# Altium schematic sheet bolid-iso-motor-control.SchDoc
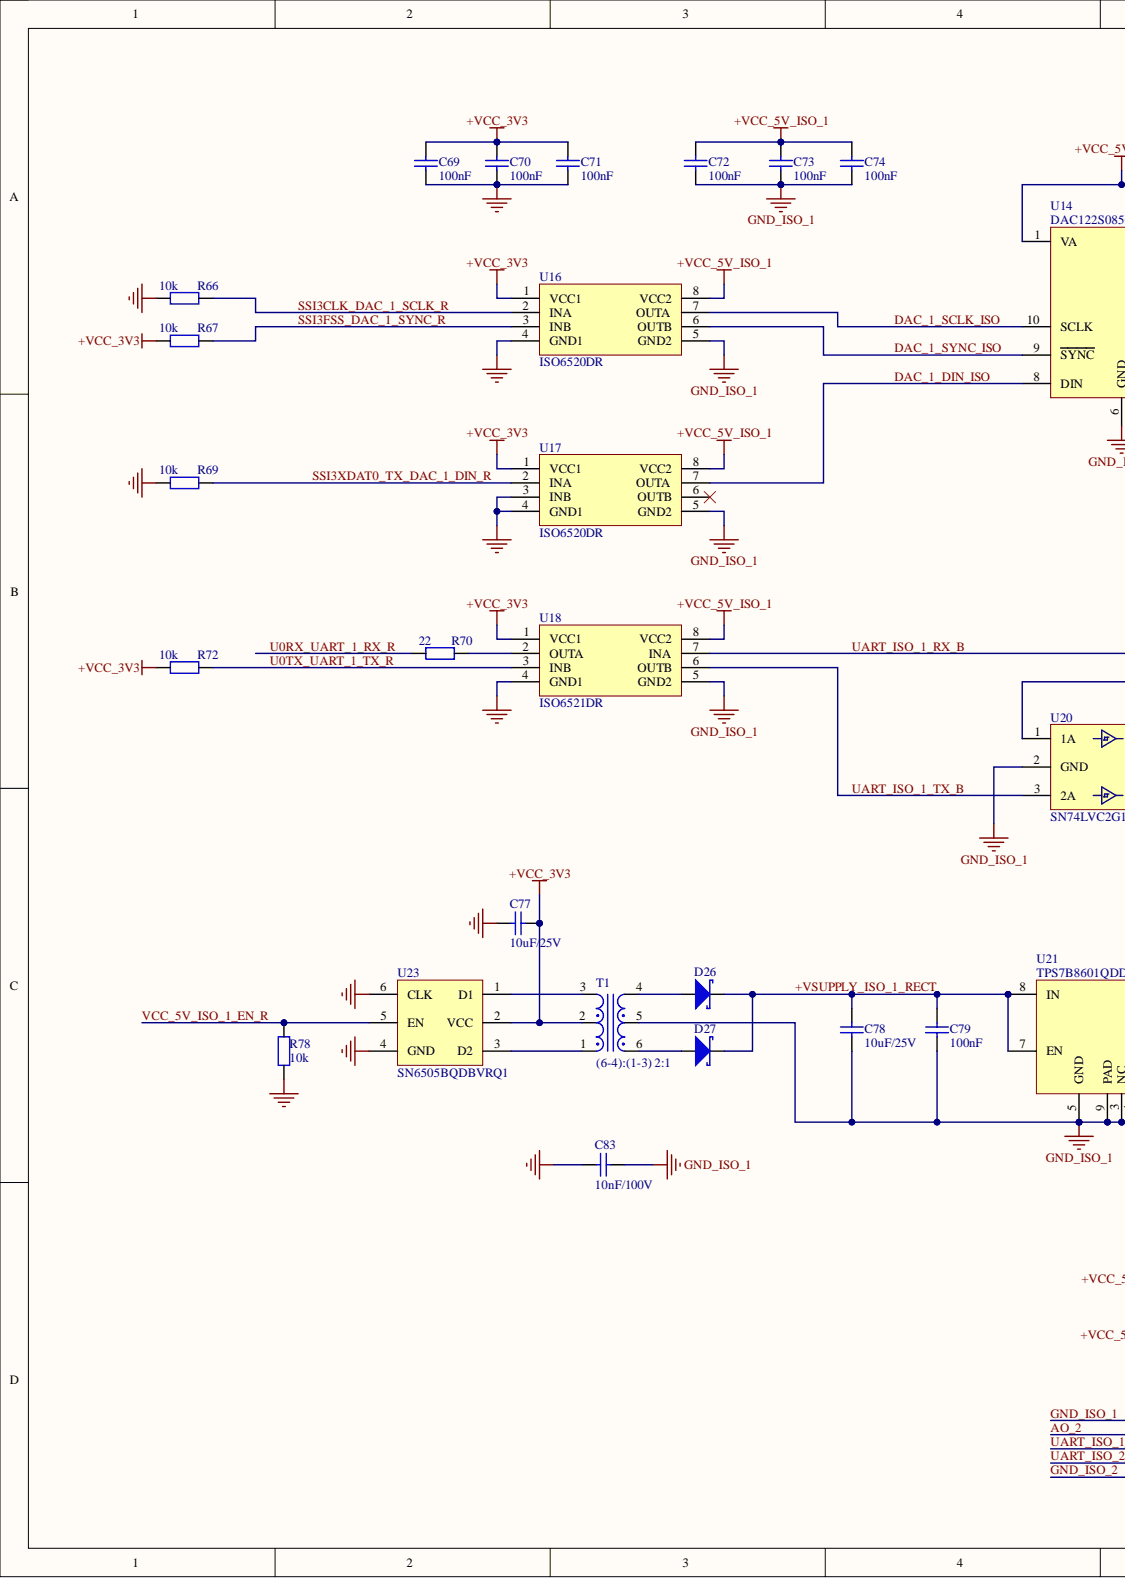
[diagram p0: bolid-iso-motor-control.SchDoc, left]
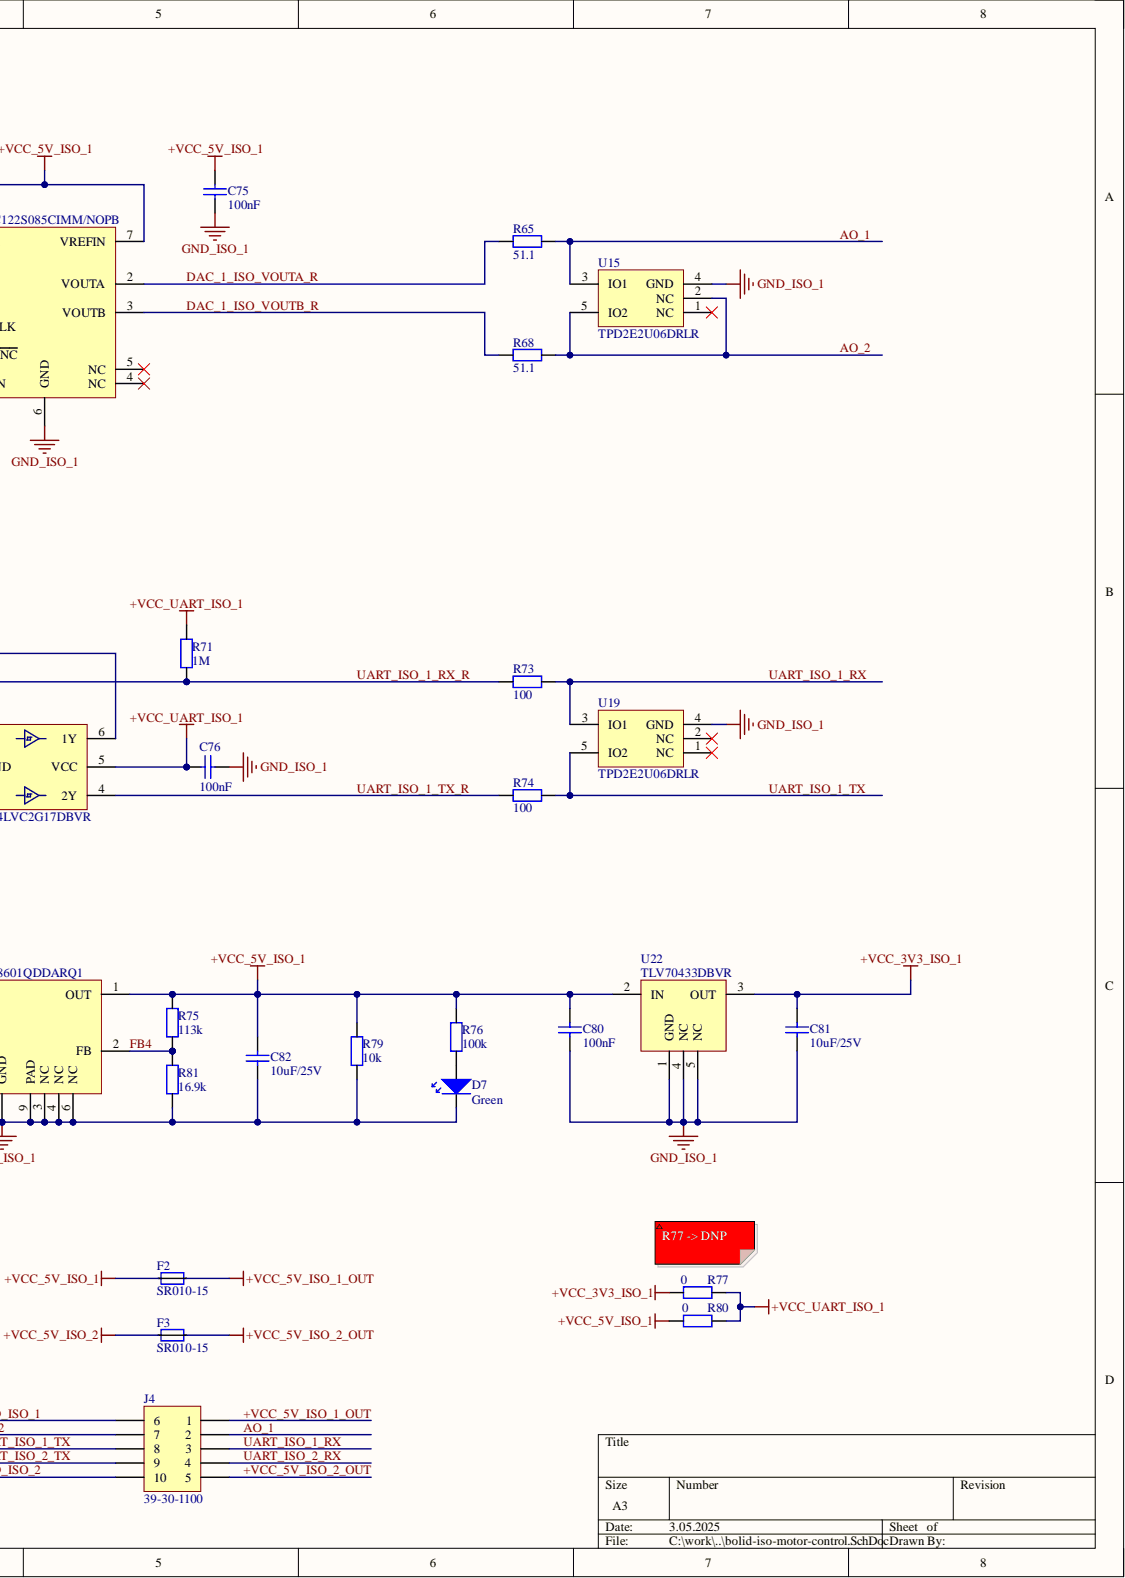
[diagram p1: bolid-iso-motor-control.SchDoc, right]

## Parts
C69  value 100nF  [C0402-CAPC100X50X55L22 - Tayio Yuden TDK]  (hidden comment: GRM155R71H104KE14D)
C70  value 100nF  [C0402-CAPC100X50X55L22 - Tayio Yuden TDK]  (hidden comment: GRM155R71H104KE14D)
C71  value 100nF  [C0402-CAPC100X50X55L22 - Tayio Yuden TDK]  (hidden comment: GRM155R71H104KE14D)
C72  value 100nF  [C0402-CAPC100X50X55L22 - Tayio Yuden TDK]  (hidden comment: GRM155R71H104KE14D)
C73  value 100nF  [C0402-CAPC100X50X55L22 - Tayio Yuden TDK]  (hidden comment: GRM155R71H104KE14D)
C74  value 100nF  [C0402-CAPC100X50X55L22 - Tayio Yuden TDK]  (hidden comment: GRM155R71H104KE14D)
C75  value 100nF  [C0402-CAPC100X50X55L22 - Tayio Yuden TDK]  (hidden comment: GRM155R71H104KE14D)
C76  value 100nF  [C0402-CAPC100X50X55L22 - Tayio Yuden TDK]  (hidden comment: GRM155R71H104KE14D)
C77  Value Displayed: 10uF/25V  [C1206-CAPC320X160X190L65N - Murata]  (hidden comment: TMK316AB7106KL-T)
C78  Value Displayed: 10uF/25V  [C1206-CAPC320X160X190L65N - Murata]  (hidden comment: TMK316AB7106KL-T)
C79  value 100nF  [C0402-CAPC100X50X55L22 - Tayio Yuden TDK]  (hidden comment: GRM155R71H104KE14D)
C80  value 100nF  [C0402-CAPC100X50X55L22 - Tayio Yuden TDK]  (hidden comment: GRM155R71H104KE14D)
C81  Value Displayed: 10uF/25V  [C1206-CAPC320X160X190L65N - Murata]  (hidden comment: TMK316AB7106KL-T)
C82  Value Displayed: 10uF/25V  [C1206-CAPC320X160X190L65N - Murata]  (hidden comment: TMK316AB7106KL-T)
C83  Value Displayed: 10nF/100V  [C0603-CAPC162X80X95L35N]  (hidden comment: GRM188R72A103KA01J)
D7  Illumination Color: Green  [D0603 DIONC166X84X36L42N Littelfuse]  (hidden comment: 150060GS75000)
D26  MBR0530T3G  [SOD-123-2L SOD375X160X128L32X60N - Fairchild]  (value not drawn)
D27  MBR0530T3G  [SOD-123-2L SOD375X160X128L32X60N - Fairchild]  (value not drawn)
F2  SR010-15  [RESC2214X10N - SR010-15]
F3  SR010-15  [RESC2214X10N - SR010-15]
J4  39-30-1100  [MOLEX Mini-Fit Jr 039301100 2x5 PEG]
R65  value 51.1  [R0402-RESC100X50X40L22N]  (hidden comment: AC0402FR-0751R1L)
R66  value 10k  [R0402-RESC100X50X40L22N]  (hidden comment: RC0402FR-0710KL)
R67  value 10k  [R0402-RESC100X50X40L22N]  (hidden comment: RC0402FR-0710KL)
R68  value 51.1  [R0402-RESC100X50X40L22N]  (hidden comment: AC0402FR-0751R1L)
R69  value 10k  [R0402-RESC100X50X40L22N]  (hidden comment: RC0402FR-0710KL)
R70  value 22  [R0402-RESC100X50X40L22N]  (hidden comment: RC0402FR-1322RL)
R71  value 1M  [R0402-RESC100X50X40L22N]  (hidden comment: AC0402FR-071ML)
R72  value 10k  [R0402-RESC100X50X40L22N]  (hidden comment: RC0402FR-0710KL)
R73  value 100  [R0402-RESC100X50X40L22N]  (hidden comment: RC0402FR-07100RL)
R74  value 100  [R0402-RESC100X50X40L22N]  (hidden comment: RC0402FR-07100RL)
R75  value 113k  [R0402-RESC100X50X40L22N]  (hidden comment: RC0402FR-07113KL)
R76  value 100k  [R0402-RESC100X50X40L22N]  (hidden comment: RC0402FR-07100KL)
R77  value 0  [R0402-RESC100X50X40L22N]  (hidden comment: RC0402JR-7D0RL)
R78  value 10k  [R0402-RESC100X50X40L22N]  (hidden comment: RC0402FR-0710KL)
R79  value 10k  [R0402-RESC100X50X40L22N]  (hidden comment: RC0402FR-0710KL)
R80  value 0  [R0402-RESC100X50X40L22N]  (hidden comment: RC0402JR-7D0RL)
R81  value 16.9k  [R0402-RESC100X50X40L22N]  (hidden comment: RC0402FR-0716K9L)
T1  value (6-4):(1-3) 2:1  [WE 760390014]  (hidden comment: 760390015)
U14  DAC122S085CIMM/NOPB  [TI VSSOP10 DGS0010A TSSOP50P490X110-10N]
U15  TPD2E2U06DRLR  [TI SOT-5X3 DRL0005A SOTFL50P160X60-5N]
U16  ISO6520DR  [TI SOIC8 D0008A SOIC8P127_490X599X175L84X41N]
U17  ISO6520DR  [TI SOIC8 D0008A SOIC8P127_490X599X175L84X41N]
U18  ISO6521DR  [TI SOIC8 D0008A SOIC8P127_490X599X175L84X41N]
U19  TPD2E2U06DRLR  [TI SOT-5X3 DRL0005A SOTFL50P160X60-5N]
U20  SN74LVC2G17DBVR  [TI SOT23 DBV0006A SOT23-6P95_280X145L45X37N]
U21  TPS7B8601QDDARQ1  [TI SOIC8 DDA0008E-C01 SOIC127P600X170_HS-9N]
U22  TLV70433DBVR  [TI SOT23 DBV0005A SOT23-5P95_280X145L45X40N]
U23  SN6505BQDBVRQ1  [TI SOT23 DBV0006A SOT23-6P95_280X145L45X37N]

## Nets
+VCC_3V3: C69.2 C70.2 C71.2 C77.2 R67.2 R72.2 T1.2 U16.1(VCC1) U17.1(VCC1) U18.1(VCC1) U23.2(VCC)
+VCC_3V3_ISO_1: C81.2 R77.1 U22.3(OUT)
+VCC_5V_ISO_1: C72.2 C73.2 C74.2 C75.2 C80.2 C82.2 F2.1 R75.2 R76.2 R79.2 R80.1 U14.1(VA) U14.7(VREFIN) U16.8(VCC2) U17.8(VCC2) U18.8(VCC2) U21.1(OUT) U22.2(IN)
+VCC_5V_ISO_2: F3.1
+VCC_UART_ISO_1: C76.2 R71.2 R77.2 R80.2 U20.5(VCC)
GND: C69.1 C70.1 C71.1 C77.1 C83.1 R66.2 R69.2 R78.1 U16.4(GND1) U17.3(INB) U17.4(GND1) U18.4(GND1) U23.4(GND) U23.6(CLK)
+VCC_5V_ISO_1_OUT: F2.2 J4.1
+VCC_5V_ISO_2_OUT: F3.2 J4.5
+VSUPPLY_ISO_1_RECT: C78.2 C79.2 D26.1 D27.1 U21.7(EN) U21.8(IN)
AO_1: J4.2 R65.2 U15.3(IO1)
AO_2: J4.7 R68.2 U15.2(NC) U15.5(IO2)
DAC_1_DIN_ISO: U14.8(DIN) U17.7(OUTA)
DAC_1_ISO_VOUTA_R: R65.1 U14.2(VOUTA)
DAC_1_ISO_VOUTB_R: R68.1 U14.3(VOUTB)
DAC_1_SCLK_ISO: U14.10(SCLK) U16.7(OUTA)
DAC_1_SYNC_ISO: U14.9(SYNC) U16.6(OUTB)
FB4: R75.1 R81.2 U21.2(FB)
GND_ISO_1: C72.1 C73.1 C74.1 C75.1 C76.1 C78.1 C79.1 C80.1 C81.1 C82.1 C83.2 D7.1 J4.6 R79.1 R81.1 T1.5 U14.6(GND) U15.4(GND) U16.5(GND2) U17.5(GND2) U18.5(GND2) U19.4(GND) U20.2(GND) U21.3(NC) U21.4(NC) U21.5(GND) U21.6(NC) U21.9(PAD) U22.1(GND) U22.4(NC) U22.5(NC)
GND_ISO_2: J4.10
SSI3CLK_DAC_1_SCLK_R: R66.1 U16.2(INA)
SSI3FSS_DAC_1_SYNC_R: R67.1 U16.3(INB)
SSI3XDAT0_TX_DAC_1_DIN_R: R69.1 U17.2(INA)
U0RX_UART_1_RX_R: R70.1
U0TX_UART_1_TX_R: R72.1 U18.3(INB)
UART_ISO_1_RX: J4.3 R73.2 U19.3(IO1)
UART_ISO_1_RX_B: U18.7(INA) U20.6(1Y)
UART_ISO_1_RX_R: R71.1 R73.1 U20.1(1A)
UART_ISO_1_TX: J4.8 R74.2 U19.5(IO2)
UART_ISO_1_TX_B: U18.6(OUTB) U20.3(2A)
UART_ISO_1_TX_R: R74.1 U20.4(2Y)
UART_ISO_2_RX: J4.4
UART_ISO_2_TX: J4.9
VCC_5V_ISO_1_EN_R: R78.2 U23.5(EN)
NetD7_2: D7.2 R76.1
NetD26_2: D26.2 T1.4
NetD27_2: D27.2 T1.6
NetR70_2: R70.2 U18.2(OUTA)
NetT1_1: T1.1 U23.3(D2)
NetT1_3: T1.3 U23.1(D1)

Not connected: U14 4(NC) 5(NC); U15 1(NC); U17 6(OUTB); U19 1(NC) 2(NC)

## Library data of the parts
C69-C76 C79 C80 = GRM155R71H104KE14D: MLCC 0402 (1005) 100nF 10% 50V X7R; Brand: Murata Electronics; Capacitance: 0.1 uF; Capacitance - pF: 100000 pF; Class: Class 2; Type: Chip Multilayer Ceramic Capacitor for General Purpose; Voltage Rating DC: 50 VDC; Capacitance - nF: 100 nF; Case Code - in: 0402; Case Code - mm: 1005; Category: Multilayer Ceramic Capacitors MLCC - SMD/SMT; Component Kind: Standard; ComponentLink1Description: https://www.mouser.com/datasheet/2/281/murata_03052018_GRM_Series_1-1310166.pdf; ComponentLink1URL: https://www.mouser.com/datasheet/2/281/murata_03052018_GRM_Series_1-1310166.pdf; Dielectric: X7R; Height: 0.5 mm; Length: 1 mm; Library Name: capacitors2.IntLib; Manufacturer: Murata Electronics; Manufacturer Part Number: GRM155R71H104KE14D; Maximum Operating Temperature: + 125 C; Minimum Operating Temperature: - 55 C; Minimum Order Quantity: 1; Package / Case: 0402 (1005 metric); Packaging: Cut Tape; Pricing 1: 1=0.090, 10=0.038, 100=0.016, 500=0.012, 1000=0.009, 2500=0.008, 5000=0.007, 10000=0.005 (EUR); Product: General Type MLCCs; Product Type: Ceramic Capacitors; Reeling: True; RoHS: RoHS Compliant; RoHS - Mouser: Y; Series: GRM; Signal Integrity: Cap Semi; Simulation: CAP; Standard Pack Qty: 10000; Stock 1: 3511637; Subcategory: Capacitors; Supplier 1: Mouser; Supplier Part Number 1: 81-GRM155R71H104KE4D; Termination: Standard; Termination Style: SMD/SMT; Tolerance: 10 %; Value Displayed: 100nF; Width: 0.5 mm
C77 C78 C81 C82 = TMK316AB7106KL-T: MLCC 1206 (3216) 10uF 10% 25V X7R; Brand: Taiyo Yuden; Class: Class 2; Type: General Purpose; Value: 10u; Voltage Rating DC: 25 VDC; Capacitance: 10 uF; Case Code - in: 1206; Case Code - mm: 3216; Category: Multilayer Ceramic Capacitors MLCC - SMD/SMT; Component Kind: Standard; ComponentLink1Description: https://www.mouser.com/datasheet/2/396/mlcc02_e-1307760.pdf; ComponentLink1URL: https://www.mouser.com/datasheet/2/396/mlcc02_e-1307760.pdf; Diameter: -; Dielectric: X7R; Height: 1.6 mm; Length: 3.2 mm; Library Name: capacitors2.IntLib; Manufacturer: Taiyo Yuden; Manufacturer Part Number: TMK316AB7106KL-T; Maximum Operating Temperature: + 125 C; Minimum Operating Temperature: - 55 C; Minimum Order Quantity: 1; Package / Case: 1206 (3216 metric); Packaging: Cut Tape; Pricing 1: 1=0.288, 10=0.130, 100=0.087, 500=0.077, 1000=0.068, 2000=0.056 (EUR); Product: General Type MLCCs; Product Type: Ceramic Capacitors; Reeling: True; RoHS: RoHS Compliant; RoHS - Mouser: Y; Series: M; Signal Integrity: Cap Semi; Simulation: CAP; Standard Pack Qty: 2000; Stock 1: 162457; Subcategory: Capacitors; Supplier 1: Mouser; Supplier Part Number 1: 963-TMK316AB7106KL-T; Termination: Standard; Termination Style: SMD/SMT; Tolerance: 10 %; Width: 1.6 mm
C83 = GRM188R72A103KA01J: MLCC 0603 (1608) 10nF 10% 100V X7R; Brand: Murata Electronics; Capacitance: 0.01 uF; Capacitance - pF: 10000 pF; Class: Class 2; Type: Chip Multilayer Ceramic Capacitor for General Purpose; Value: 10n; Voltage Rating DC: 100 VDC; Capacitance - nF: 10 nF; Case Code - in: 0603; Case Code - mm: 1608; Category: Multilayer Ceramic Capacitors MLCC - SMD/SMT; Component Kind: Standard; ComponentLink1Description: https://www.mouser.com/datasheet/2/281/1/GRM188R72A103KA01_01A-1985966.pdf; ComponentLink1URL: https://www.mouser.com/datasheet/2/281/1/GRM188R72A103KA01_01A-1985966.pdf; Dielectric: X7R; Height: 0.8 mm; Length: 1.6 mm; Library Name: capacitors2.IntLib; Manufacturer: Murata Electronics; Manufacturer Part Number: GRM188R72A103KA01J; Maximum Operating Temperature: + 125 C; Minimum Operating Temperature: - 55 C; Minimum Order Quantity: 1; Package / Case: 0603 (1608 metric); Packaging: Cut Tape; Pricing 1: 1=0.085, 10=0.049, 100=0.021, 500=0.015, 1000=0.011, 5000=0.010, 10000=0.008, 20000=0.007 (EUR); Product: General Type MLCCs; Product Type: Ceramic Capacitors; Reeling: True; RoHS: RoHS Compliant; RoHS - Mouser: Y; Series: GRM; Signal Integrity: Cap Semi; Simulation: CAP; Standard Pack Qty: 10000; Stock 1: 161253; Subcategory: Capacitors; Supplier 1: Mouser; Supplier Part Number 1: 81-GRM188R72A103KA1J; Termination: Standard; Termination Style: SMD/SMT; Tolerance: 10 %; Width: 0.8 mm
D7 = 150060GS75000: LED 0603 (1608) Green; Brand: Wurth Elektronik; If - Forward Current: 20 mA; Lens Color/Style: Water Clear; Lens Shape: Rectangular; Luminous Intensity: 430 mcd; Peak Wavelength: 515 nm; Power Rating: 105 mW; Vf - Forward Voltage: 3.2 V; Viewing Angle: 140 deg; Vr - Reverse Voltage: 5 V; Wavelength/Color Temperature: 520 nm; Category: Standard LEDs - SMD; Component Kind: Standard; ComponentLink1Description: https://www.mouser.com/datasheet/2/445/150060GS75000-368921.pdf; ComponentLink1URL: https://www.mouser.com/datasheet/2/445/150060GS75000-368921.pdf; Height: 0.7 mm; Length: 1.6 mm; Lens Dimensions: 1.1 mm x 0.8 mm; Lens Transparency: Water Clear; Library Name: semiconductors2.IntLib; Manufacturer: Wurth Elektronik; Manufacturer Part Number: 150060GS75000; Maximum Operating Temperature: + 85 C; Minimum Operating Temperature: - 40 C; Minimum Order Quantity: 1; Moisture Sensitive: Yes; Mounting Style: SMD/SMT; Package / Case: 0603 (1608 metric); Packaging: Cut Tape; Pricing 1: 1=0.130, 10=0.126, 50=0.115, 100=0.099, 500=0.085, 4000=0.085 (EUR); Product: Green LEDs; Product Type: LED - Standard; Reeling: True; RoHS: RoHS Compliant; RoHS - Mouser: Y; Series: WL-SMCW; Signal Integrity: Diode; Simulation: DIODE; Standard Pack Qty: 4000; Stock 1: 17312; Subcategory: LEDs; Supplier 1: Mouser; Supplier Part Number 1: 710-150060GS75000; Width: 0.8 mm
D26 D27 = MBR0530T3G: ON Semi MBR0530T3G; SMT Schottky Diode; 30V 500mA; 2-Pin SOD-123; Manufacturer: ON Semiconductor; Category: Schottky Diodes; Component Kind: Standard; ComponentLink1Description: https://datasheet.ciiva.com/6381/mbr0530t1-d-6381904.pdf?src-supplier=Digikey; ComponentLink1URL: https://datasheet.ciiva.com/6381/mbr0530t1-d-6381904.pdf?src-supplier=Digikey; ComponentLink2Description: https://datasheet.ciiva.com/7962/85890-7962703.pdf?src-supplier=Element14; ComponentLink2URL: https://datasheet.ciiva.com/7962/85890-7962703.pdf?src-supplier=Element14; ComponentLink3Description: https://datasheet.ciiva.com/8294/mbr0530t1-d-8294572.pdf?src-supplier=Avnet; ComponentLink3URL: https://datasheet.ciiva.com/8294/mbr0530t1-d-8294572.pdf?src-supplier=Avnet; ComponentLink4Description: https://datasheet.ciiva.com/15144/getdatasheetpartid-86762-15144599.pdf?src-supplier=Verical; ComponentLink4URL: https://datasheet.ciiva.com/15144/getdatasheetpartid-86762-15144599.pdf?src-supplier=Verical; ComponentLink5Description: https://datasheet.ciiva.com/6381/mbr0530t1-d-6381902.pdf?src-supplier=Digikey; ComponentLink5URL: https://datasheet.ciiva.com/6381/mbr0530t1-d-6381902.pdf?src-supplier=Digikey; Library Name: semiconductors2.IntLib; Manufacturer Part Number: MBR0530T3G; Pricing 1: 1=0,19, 10=0,126, 50=0,126, 100=0,065, 1000=0,053, 10000=0,041 (USD); RoHS: Yes; Signal Integrity: Diode; Simulation: DIODE; Stock 1: 167531; Supplier 1: Mouser; Supplier Part Number 1: 863-MBR0530T3G
F2 F3 = SR010-15: PTC Fuse Hold Current 0.10A, Trip Current 0.30A VMax 15V; Manufacturer: Excel Cell; Component Kind: Standard; Library Name: misc2.IntLib; Manufacturer Part Number: SR010-15; RoHS: No; Stock 1: 5574; Supplier 1: TME; Supplier Part Number 1: SR010-15
J4 = 039301100: Molex MiniFit Jr 2x5 Peg Rt Angle Header Tin Plate W/pcb Mount Peg 94V-2 10 Circt | Molex Incorporated 39-30-1100; Gender: 29Gender; Insulation Resistance: 1GR; Number of Circuits: 8NUMBER; Number of Ports: 1NUMBER; Voltage Rating (DC): 600V; Category: Headers and Wire Housings; Component Kind: Standard; ComponentLink1Description: https://datasheet.ciiva.com/30070/136790039301100-pcb-headers-30070445.pdf?src-supplier=Onlinecomponents.com; ComponentLink1URL: https://datasheet.ciiva.com/30070/136790039301100-pcb-headers-30070445.pdf?src-supplier=Onlinecomponents.com; ComponentLink2Description: https://datasheet.ciiva.com/11748/0900766b805f2c40-11748682.pdf?src-supplier=Rs; ComponentLink2URL: https://datasheet.ciiva.com/11748/0900766b805f2c40-11748682.pdf?src-supplier=Rs; Depth: 19.4mm; Fastening Type: 192FasteningType; Housing Color: 50Colour; Lead Free: 5697LeadFree; Library Name: connectors2.IntLib; Manufacturer: Molex; Manufacturer Part Number: 39-30-1100; Pricing 1: 1=0,85, 10=0,769, 50=0,769, 100=0,739, 1000=0,739, 10000=0,739 (USD); RoHS: Yes; Stock 1: 3513; Supplier 1: Mouser; Supplier Part Number 1: 538-39-30-1100
R65 R68 = AC0402FR-0751R1L: RES 0402 (1005) 51.1 ohm 1% 0.0625W 50V Thick Film; Manufacturer: Yageo; Max Power Dissipation: 63mW; Power Rating: 62.5mW; Resistance: 51.1R; Temperature Coefficient: 100ppm/°C; Case Code (Imperial): 0402; Case Code (Metric): 1005; Case/Package: 0402; Category: Chip SMD Resistors; Component Kind: Standard; ComponentLink1Description: http://www.mouser.com/ds/2/447/yageodocoutput-1111992.pdf?src-supplier=Mouser; ComponentLink1URL: http://www.mouser.com/ds/2/447/yageodocoutput-1111992.pdf?src-supplier=Mouser; ComponentLink2Description: http://www.yageo.com/NewPortal/yageodocoutput?fileName=/pdf/R-Chip/PYu-AC_51_RoHS_L_6.pdf&src-supplier=Digi-Key; ComponentLink2URL: http://www.yageo.com/NewPortal/yageodocoutput?fileName=/pdf/R-Chip/PYu-AC_51_RoHS_L_6.pdf&src-supplier=Digi-Key; Features: Moisture Resistant,Automotive AEC-Q200; Height: 0.015inch; Length: 0.039inch; Library Name: resistors2.IntLib; Manufacturer Part Number: AC0402FR-0751R1L; Max Operating Temperature: 155°C; Min Operating Temperature: -55°C; Number of Terminations: 2; Packaging: Tape and Reel; Pricing 1: 1=0,10000, 10=0,01500, 50=0,01500, 100=0,00700, 1000=0,00300, 10000=0,00200 (USD); Resistor Type: Thick Film; RoHS: Yes; RoHS Compliant: Yes; Series: AC; Signal Integrity: Res; Simulation: RESISTOR; Stock 1: 57995; Supplier 1: Mouser; Supplier Part Number 1: 603-AC0402FR-0751R1L; Termination: SMD/SMT; Tolerance: 1%; Voltage Rating: 50V; Width: 0.02inch
R66 R67 R69 R72 R78 R79 = RC0402FR-0710KL: RES 0402 (1005) 10.0k 1% 0.0625W 50V Thick Film; Brand: Yageo; Power Rating: 62.5 mW, 125 mW; Resistance: 10 kOhms; Temperature Coefficient: 100 PPM / C; Application: High Reliability; Case Code - in: 0402; Case Code - mm: 1005; Category: Thick Film Resistors - SMD; Component Kind: Standard; ComponentLink1Description: https://www.mouser.com/datasheet/2/447/PYu-RC_Group_51_RoHS_L_10-1664068.pdf; ComponentLink1URL: https://www.mouser.com/datasheet/2/447/PYu-RC_Group_51_RoHS_L_10-1664068.pdf; Features: -; Height: 0.35 mm; Length: 1 mm; Library Name: resistors2.IntLib; Manufacturer: Yageo; Manufacturer Part Number: RC0402FR-0710KL; Maximum Operating Temperature: + 155 C; Minimum Operating Temperature: - 55 C; Minimum Order Quantity: 1; Mounting Style: PCB Mount; Package / Case: -; Packaging: Cut Tape; Pricing 1: 1=0.090, 10=0.011, 100=0.004, 1000=0.003, 10000=0.003 (EUR); Product: Thick Film Resistors SMD; Product Type: Thick Film Resistors; Reeling: True; RoHS: RoHS Compliant; RoHS - Mouser: Y; Series: RC; Signal Integrity: Res; Simulation: RESISTOR; Standard Pack Qty: 10000; Stock 1: 20942447; Subcategory: Resistors; Supplier 1: Mouser; Supplier Part Number 1: 603-RC0402FR-0710KL; Technology: Thick Film; Termination Style: SMD/SMT; Tolerance: 1 %; Type: General Purpose; Voltage Rating: 50 V; Width: 0.5 mm
R70 = RC0402FR-1322RL: RES 0402 (1005) 22.0 ohm 1% 0.0625W 50V Thick Film; Manufacturer: Yageo; Power Rating: 62.5mW; Resistance: 22R; Temperature Coefficient: 100ppm/°C; Case Code (Imperial): 0402; Case Code (Metric): 1005; Case/Package: 0402; Category: Chip SMD Resistors; Component Kind: Standard; ComponentLink1Description: https://datasheet.ciiva.com/17423/pyu-rc0402-51-rohs-l-6-r-17423721.pdf?src-supplier=Future+Electronics; ComponentLink1URL: https://datasheet.ciiva.com/17423/pyu-rc0402-51-rohs-l-6-r-17423721.pdf?src-supplier=Future+Electronics; ComponentLink2Description: https://datasheet.ciiva.com/8890/pyu-rc0402-51-rohs-l-6-8890187.pdf?src-supplier=Avnet; ComponentLink2URL: https://datasheet.ciiva.com/8890/pyu-rc0402-51-rohs-l-6-8890187.pdf?src-supplier=Avnet; Contact Plating: Tin,Matte; Height: 0.35mm; Lead Free: Lead Free; Length: 1mm; Library Name: resistors2.IntLib; Manufacturer Part Number: RC0402FR-1322RL; Max Operating Temperature: 155°C; Min Operating Temperature: -55°C; Packaging: Tape and Reel; Pricing 1: 1=0,10000, 10=0,01200, 50=0,01200, 100=0,00500, 1000=0,00300, 10000=0,00300 (USD); Radiation Hardening: No; Resistor Type: Thick Film; RoHS: Yes; RoHS Compliant: Yes; Series: RC; Signal Integrity: Res; Simulation: RESISTOR; Size Code: 0402; Stock 1: 130563; Supplier 1: Mouser; Supplier Part Number 1: 603-RC0402FR-1322RL; Termination: SMD/SMT; Tolerance: 1%; Voltage Rating: 50V; Weight: 2.3E-05oz; Width: 0.5mm
R71 = AC0402FR-071ML: RES 0402 (1005) 1.00M 1% 0.0625W 50V Thick Film; Brand: YAGEO; Power Rating: 62.5 mW (1/16 W); Qualification: AEC-Q200; Resistance: 1 MOhms; Temperature Coefficient: 100 PPM / C; Application: Automotive Grade; Case Code - in: 0402; Case Code - mm: 1005; Category: Thick Film Resistors - SMD; Component Kind: Standard; ComponentLink1Description: https://www.mouser.com/datasheet/2/447/Yageo_PYu_AC_51_RoHS_L_7_1714230-1874691.pdf; ComponentLink1URL: https://www.mouser.com/datasheet/2/447/Yageo_PYu_AC_51_RoHS_L_7_1714230-1874691.pdf; Height: 0.32 mm; Length: 1 mm; Library Name: resistors2.IntLib; Manufacturer: YAGEO; Manufacturer Part Number: AC0402FR-071ML; Maximum Operating Temperature: + 155 C; Minimum Operating Temperature: - 55 C; Minimum Order Quantity: 1; Mounting Style: PCB Mount; Packaging: Cut Tape; Pricing 1: 1=0.085, 10=0.019, 100=0.008, 1000=0.004, 2500=0.003, 10000=0.003 (EUR); Product: Thick Film Resistors General Purpose; Product Type: Thick Film Resistors; Reeling: True; RoHS: RoHS Compliant By Exemption; RoHS - Mouser: E; Series: AC; Signal Integrity: Res; Simulation: RESISTOR; Standard Pack Qty: 10000; Stock 1: 142366; Subcategory: Resistors; Supplier 1: Mouser; Supplier Part Number 1: 603-AC0402FR-071ML; Technology: Thick Film; Termination Style: SMD/SMT; Tolerance: 1 %; Type: General Purpose Resistor; Voltage Rating: 50 V; Width: 0.5 mm
R73 R74 = RC0402FR-07100RL: RES 0402 (1005) 100.0 ohm 1% 0.0625W 50V Thick Film; Brand: Yageo; Power Rating: 62.5 mW, 125 mW; Resistance: 100 Ohms; Temperature Coefficient: 100 PPM / C; Application: High Reliability; Case Code - in: 0402; Case Code - mm: 1005; Category: Thick Film Resistors - SMD; Component Kind: Standard; ComponentLink1Description: https://www.mouser.com/datasheet/2/447/PYu-RC_Group_51_RoHS_L_10-1664068.pdf; ComponentLink1URL: https://www.mouser.com/datasheet/2/447/PYu-RC_Group_51_RoHS_L_10-1664068.pdf; Features: -; Height: 0.35 mm; Length: 1 mm; Library Name: resistors2.IntLib; Manufacturer: Yageo; Manufacturer Part Number: RC0402FR-07100RL; Maximum Operating Temperature: + 155 C; Minimum Operating Temperature: - 55 C; Minimum Order Quantity: 1; Mounting Style: PCB Mount; Package / Case: -; Packaging: Cut Tape; Pricing 1: 1=0.090, 10=0.011, 100=0.004, 1000=0.003, 10000=0.003 (EUR); Product: Thick Film Resistors SMD; Product Type: Thick Film Resistors; Reeling: True; RoHS: RoHS Compliant; RoHS - Mouser: Y; Series: RC; Signal Integrity: Res; Simulation: RESISTOR; Standard Pack Qty: 10000; Stock 1: 3714956; Subcategory: Resistors; Supplier 1: Mouser; Supplier Part Number 1: 603-RC0402FR-07100RL; Technology: Thick Film; Termination Style: SMD/SMT; Tolerance: 1 %; Type: General Purpose; Voltage Rating: 50 V; Width: 0.5 mm
R75 = RC0402FR-07113KL: RES 0402 (1005) 113.0k 1% 0.0625W 50V Thick Film; Manufacturer: Yageo; Category: Chip SMD Resistors; Component Kind: Standard; ComponentLink1Description: https://datasheet.ciiva.com/16744/pyu-rc0402-51-rohs-l-6-r-16744521.pdf?src-supplier=Future+Electronics; ComponentLink1URL: https://datasheet.ciiva.com/16744/pyu-rc0402-51-rohs-l-6-r-16744521.pdf?src-supplier=Future+Electronics; ComponentLink2Description: https://datasheet.ciiva.com/2452/pyu-r-int-thick-7-2452604.pdf?src-supplier=Digikey; ComponentLink2URL: https://datasheet.ciiva.com/2452/pyu-r-int-thick-7-2452604.pdf?src-supplier=Digikey; Lead Free: 5697LeadFree; Library Name: resistors2.IntLib; Manufacturer Part Number: RC0402FR-07113KL; Pricing 1: 1=0,1, 10=0,007, 50=0,007, 100=0,004, 1000=0,003, 10000=0,002 (USD); RoHS: Yes; Signal Integrity: Res; Simulation: RESISTOR; Stock 1: 42603; Supplier 1: Mouser; Supplier Part Number 1: 603-RC0402FR-07113KL; Voltage Rating (DC): 50V
R76 = RC0402FR-07100KL: RES 0402 (1005) 100.0k 1% 0.0625W 50V Thick Film; Brand: Yageo; Power Rating: 62.5 mW, 125 mW; Resistance: 100 kOhms; Temperature Coefficient: 100 PPM / C; Application: High Reliability; Case Code - in: 0402; Case Code - mm: 1005; Category: Thick Film Resistors - SMD; Component Kind: Standard; ComponentLink1Description: https://www.mouser.com/datasheet/2/447/PYu-RC_Group_51_RoHS_L_10-1664068.pdf; ComponentLink1URL: https://www.mouser.com/datasheet/2/447/PYu-RC_Group_51_RoHS_L_10-1664068.pdf; Features: -; Height: 0.35 mm; Length: 1 mm; Library Name: resistors2.IntLib; Manufacturer: Yageo; Manufacturer Part Number: RC0402FR-07100KL; Maximum Operating Temperature: + 155 C; Minimum Operating Temperature: - 55 C; Minimum Order Quantity: 1; Mounting Style: PCB Mount; Package / Case: -; Packaging: Cut Tape; Pricing 1: 1=0.090, 10=0.011, 100=0.004, 1000=0.003, 10000=0.003 (EUR); Product: Thick Film Resistors SMD; Product Type: Thick Film Resistors; Reeling: True; RoHS: RoHS Compliant; RoHS - Mouser: Y; Series: RC; Signal Integrity: Res; Simulation: RESISTOR; Standard Pack Qty: 10000; Stock 1: 2491111; Subcategory: Resistors; Supplier 1: Mouser; Supplier Part Number 1: 603-RC0402FR-07100KL; Technology: Thick Film; Termination Style: SMD/SMT; Tolerance: 1 %; Type: General Purpose; Voltage Rating: 50 V; Width: 0.5 mm
R77 R80 = RC0402JR-7D0RL: RES 0402 (1005) 0.00 ohm 1% 0.0625W 50V Thick Film; Manufacturer: Yageo; Resistance: 0mR; Tolerance: 5%; Case/Package: 0402; Category: Chip SMD Resistors; Component Kind: Standard; Library Name: resistors2.IntLib; Manufacturer Part Number: RC0402JR-7D0RL; Pricing 1: 1=0,10000, 10=0,01400, 50=0,01400, 100=0,00600, 1000=0,00300, 10000=0,00200 (USD); RoHS: Yes; RoHS Compliant: Yes; Signal Integrity: Res; Simulation: RESISTOR; Stock 1: 114874; Supplier 1: Mouser; Supplier Part Number 1: 603-RC0402JR-7D0RL
R81 = RC0402FR-0716K9L: RES 0402 (1005) 16.9k 1% 0.0625W 50V Thick Film; Manufacturer: Yageo; Max Power Dissipation: 63mW; Power Rating: 62.5mW; Resistance: 16.9kR; Temperature Coefficient: 100ppm/°C; Case Code (Imperial): 0402; Case Code (Metric): 1005; Case/Package: 0402; Category: Chip SMD Resistors; Component Kind: Standard; ComponentLink1Description: https://datasheet.ciiva.com/12561/pyu-rc0402-51-rohs-l-6-r-8721-12561757.pdf?src-supplier=Mouser; ComponentLink1URL: https://datasheet.ciiva.com/12561/pyu-rc0402-51-rohs-l-6-r-8721-12561757.pdf?src-supplier=Mouser; ComponentLink2Description: https://datasheet.ciiva.com/17073/pyu-rc0402-51-rohs-l-6-r-17073310.pdf?src-supplier=Future+Electronics; ComponentLink2URL: https://datasheet.ciiva.com/17073/pyu-rc0402-51-rohs-l-6-r-17073310.pdf?src-supplier=Future+Electronics; Contact Plating: Tin,Matte; Features: Moisture Resistant; Height: 0.016inch; Lead Free: Lead Free; Length: 0.039inch; Library Name: resistors2.IntLib; Manufacturer Part Number: RC0402FR-0716K9L; Max Operating Temperature: 155°C; Min Operating Temperature: -55°C; Number of Terminations: 2; Package Quantity: 10000; Packaging: Tape and Reel; Pricing 1: 1=0,1, 10=0,008, 50=0,008, 100=0,005, 1000=0,005, 10000=0,002 (USD); RoHS: Yes; RoHS Compliant: Yes; Series: RC; Signal Integrity: Res; Simulation: RESISTOR; Size Code: 0402; Stock 1: 109384; Supplier 1: Mouser; Supplier Part Number 1: 603-RC0402FR-0716K9L; Termination: SMD/SMT; Tolerance: 1%; Voltage Rating: 50V; Voltage Rating (DC): 50V; Width: 0.5mm
T1 = 760390015: Transformer 2:1 11VuS 150mAAC Power Transformers MID-SN6501 TI Driver Toroid .475mH 3.3VDC; Brand: Wurth Elektronik / Midcom; Inductance: 475 uH; Operating Frequency: 250 kHz to 550 kHz; Power Rating: 1.8 W; Primary Voltage Rating: 3.3 V; Primary Winding: Dual Primary Winding; Secondary Current Rating: 350 mA; Secondary Voltage Rating: 5 V; Secondary Winding: Dual Secondary Winding; Turns Ratio: 0.043055556; Type: Push-Pull; Value: (6-4):(1-3) 2:1; Voltage Time - Et: 11 uVs; Category: Power Transformers; Component Kind: Standard; ComponentLink1Description: https://www.mouser.com/datasheet/2/445/760390015-221218.pdf; ComponentLink1URL: https://www.mouser.com/datasheet/2/445/760390015-221218.pdf; Height: 4.19 mm; Length: 6.73 mm; Library Name: inductors2.IntLib; Manufacturer: Wurth Elektronik / Midcom; Manufacturer Part Number: 760390015; Maximum Operating Temperature: + 125 C; Minimum Operating Temperature: - 40 C; Minimum Order Quantity: 1; Mounting Style: SMD/SMT; Operating Temperature Range: - 40 C to + 125 C; Packaging: Cut Tape; Pricing 1: 1=1.90, 10=1.71, 50=1.55, 100=1.42, 500=1.34, 1200=1.34 (EUR); Product: Power; Product Type: Audio & Signal Transformers; Reeling: True; RoHS: RoHS Compliant; RoHS - Mouser: Y; Series: MID-PPTI; Standard Pack Qty: 1200; Stock 1: 4102; Subcategory: Transformers; Supplier 1: Mouser; Supplier Part Number 1: 710-760390015; Termination Style: SMD/SMT; Width: 10.05 mm
U14 = DAC122S085CIMM/NOPB: 12-Bit Micro Power DUAL Digital-to-Analog Converter with ail-to- ail Output 10-VSSOP -40 to 105; Manufacturer: TI National Semiconductor; Category: Digital to Analog Converters (DACs); Component Kind: Standard; ComponentLink1Description: https://datasheet.ciiva.com/41460/001186508-da-01-en-ic-dac-1-dac122s085cimm-nopb-msop-10-tid-41460669.pdf?src-supplier=Conrad; ComponentLink1URL: https://datasheet.ciiva.com/41460/001186508-da-01-en-ic-dac-1-dac122s085cimm-nopb-msop-10-tid-41460669.pdf?src-supplier=Conrad; ComponentLink2Description: https://datasheet.ciiva.com/3041/dac122s085-3041128.pdf?src-supplier=Avnet; ComponentLink2URL: https://datasheet.ciiva.com/3041/dac122s085-3041128.pdf?src-supplier=Avnet; ComponentLink3Description: https://datasheet.ciiva.com/49118/1851000-49118556.pdf?src-supplier=Element14; ComponentLink3URL: https://datasheet.ciiva.com/49118/1851000-49118556.pdf?src-supplier=Element14; ComponentLink4Description: https://datasheet.ciiva.com/15146/getdatasheetpartid-142727-15146634.pdf?src-supplier=Verical; ComponentLink4URL: https://datasheet.ciiva.com/15146/getdatasheetpartid-142727-15146634.pdf?src-supplier=Verical; ComponentLink5Description: https://datasheet.ciiva.com/6665/dac122s085-6665055.pdf?src-supplier=Digikey; ComponentLink5URL: https://datasheet.ciiva.com/6665/dac122s085-6665055.pdf?src-supplier=Digikey; Library Name: ics2.IntLib; Manufacturer Part Number: DAC122S085CIMM/NOPB; Pricing 1: 1=5,24, 10=3,75, 50=3,75, 100=3,36, 1000=3,07, 10000=2,94 (USD); RoHS: Yes; Stock 1: 2044; Supplier 1: Mouser; Supplier Part Number 1: 926-DAC122S085CIMMNO
U15 U19 = TPD2E2U06DRLR: TVS DIODE 5.5VWM 12.4VC 5SOT; Manufacturer: Texas Instruments; Breakdown Voltage: 8.5V; Capacitance: 1.9pF; Clamping Voltage: 12.4V; Direction: Unidirectional; Interface: LVDS,USB,Ethernet; Min Breakdown Voltage: 6.5V; Number of Channels: 2; Operating Supply Voltage: 5.5V; Peak Pulse Current: 5.5A; Peak Pulse Power: 85W; Power Line Protection: Yes; Case/Package: SOT-5; Category: Integrated Circuits (ICs); Component Kind: Standard; ComponentLink1Description: https://datasheet.ciiva.com/48533/tpd2e2u06-48533343.pdf?src-supplier=Avnet; ComponentLink1URL: https://datasheet.ciiva.com/48533/tpd2e2u06-48533343.pdf?src-supplier=Avnet; Lead Free: Lead Free; Library Name: semiconductors2.IntLib; Manufacturer Part Number: TPD2E2U06DRLR; Max Operating Temperature: 125°C; Min Operating Temperature: -40°C; Mount: Surface Mount; Number of Pins: 5; Number of Unidirectional Channels: 2; Package Quantity: 4000; Packaging: Tape and Reel; Polarity: Unidirectional; Pricing 1: 1=0,49, 10=0,356, 50=0,356, 100=0,254, 1000=0,173, 10000=0,159 (USD); Reach SVHC Compliant: No; Reverse Standoff Voltage: 5.5V; RoHS: Yes; RoHS Compliant: Yes; Series: -; Stock 1: 73856; Supplier 1: Mouser; Supplier Part Number 1: 595-TPD2E2U06DRLR; Termination: SMD/SMT; Working Voltage: 5.5V
U16 U17 = ISO6520DR: Functional isolation dual-channel 2/0 digital isolator 8-SOIC -40 to 125; Manufacturer: Texas Instruments; Case/Package: SOIC; Component Kind: Standard; Height: 1.75mm; Length: 4.9mm; Library Name: ics2.IntLib; Manufacturer Part Number: ISO6520DR; Number of Pins: 8; Pricing 1: 1=1,38, 10=0,862, 50=0,862, 100=0,695, 1000=0,635, 10000=0,549 (USD); RoHS: No; Stock 1: 2904; Supplier 1: Mouser; Supplier Part Number 1: 595-ISO6520DR; Thickness: 1.58mm; Width: 3.91mm
U18 = ISO6521DR: Functional isolation dual-channel 1/1 digital isolator 8-SOIC -40 to 125; Manufacturer: Texas Instruments; Case/Package: SOIC; Component Kind: Standard; Height: 1.75mm; Length: 4.9mm; Library Name: ics2.IntLib; Manufacturer Part Number: ISO6521DR; Number of Pins: 8; Pricing 1: 1=1,38, 10=0,862, 50=0,862, 100=0,695, 1000=0,635, 10000=0,549 (USD); RoHS: No; Stock 1: 2524; Supplier 1: Mouser; Supplier Part Number 1: 595-ISO6521DR; Thickness: 1.58mm; Width: 3.91mm
U20 = SN74LVC2G17DBVR: 2-ch, 1.65-V to 5.5-V buffers with Schmitt-Trigger inputs 6-SOT-23 -40 to 125; Manufacturer: Texas Instruments; High Level Output Current: -32mA; Logic Function: Schmitt Trigger,Buffer; Low Level Output Current: 32mA; Max Supply Voltage: 5.5V; Min Supply Voltage: 1.65V; Nominal Supply Current: 250mA; Number of Bits: 2; Number of Bits per Element: 1; Polarity: Non-Inverting; Propagation Delay: 4.3ns; Quiescent Current: 10uA; Turn-On Delay Time: 9.3ns; Case/Package: SOT-23; Category: Buffers, Drivers and Transceivers; Component Kind: Standard; ComponentLink1Description: https://datasheet.ciiva.com/23943/1772447-23943379.pdf?src-supplier=Newark; ComponentLink1URL: https://datasheet.ciiva.com/23943/1772447-23943379.pdf?src-supplier=Newark; ComponentLink2Description: https://datasheet.ciiva.com/34301/1046318-34301918.pdf?src-supplier=Jameco; ComponentLink2URL: https://datasheet.ciiva.com/34301/1046318-34301918.pdf?src-supplier=Jameco; ComponentLink3Description: https://datasheet.ciiva.com/7744/1781649-7744027.pdf?src-supplier=Element14; ComponentLink3URL: https://datasheet.ciiva.com/7744/1781649-7744027.pdf?src-supplier=Element14; ComponentLink4Description: https://datasheet.ciiva.com/7744/1781649-7744028.pdf?src-supplier=Element14; ComponentLink4URL: https://datasheet.ciiva.com/7744/1781649-7744028.pdf?src-supplier=Element14; ComponentLink5Description: https://datasheet.ciiva.com/28594/1772447-28594828.pdf?src-supplier=Element14; ComponentLink5URL: https://datasheet.ciiva.com/28594/1772447-28594828.pdf?src-supplier=Element14; ComponentLink6Description: https://datasheet.ciiva.com/46439/1857109-46439627.pdf?src-supplier=Element14; ComponentLink6URL: https://datasheet.ciiva.com/46439/1857109-46439627.pdf?src-supplier=Element14; ComponentLink7Description: https://datasheet.ciiva.com/1833/sn74lvc2g17-1833740.pdf?src-supplier=Digikey; ComponentLink7URL: https://datasheet.ciiva.com/1833/sn74lvc2g17-1833740.pdf?src-supplier=Digikey; ComponentLink8Description: https://datasheet.ciiva.com/1833/sn74lvc2g17-1833739.pdf?src-supplier=Digikey; ComponentLink8URL: https://datasheet.ciiva.com/1833/sn74lvc2g17-1833739.pdf?src-supplier=Digikey; ComponentLink9Description: https://datasheet.ciiva.com/1833/sn74lvc2g17-1833741.pdf?src-supplier=Digikey; ComponentLink9URL: https://datasheet.ciiva.com/1833/sn74lvc2g17-1833741.pdf?src-supplier=Digikey; Lead Free: Lead Free; Length: 2.9mm; Library Name: ics2.IntLib; Manufacturer Part Number: SN74LVC2G17DBVR; Max Operating Temperature: 125°C; Min Operating Temperature: -40°C; Mount: Surface Mount; Number of Channels: 2; Number of Elements: 2; Number of Gates: 2; Number of Input Lines: 2; Number of Inputs: 2; Number of Output Lines: 2; Number of Outputs: 2; Number of Pins: 6; Package Quantity: 1; Packaging: Tape and Reel; Pricing 1: 1=0,17, 10=0,094, 50=0,094, 100=0,063, 1000=0,062, 10000=0,051 (USD); Radiation Hardening: No; Reach SVHC Compliant: No; RoHS: Yes; RoHS Compliant: Yes; Series: 74LVC; Stock 1: 195310; Supplier 1: Mouser; Supplier Part Number 1: 595-SN74LVC2G17DBVR; Technology: CMOS; Termination: SMD/SMT; Thickness: 1.2mm; Width: 1.6mm
U21 = TPS7B8601QDDARQ1: Automotive 500-mA, 40-V, ultra-low-IQ, low-dropout (LDO) linear regulator with power good 8-SO PowerPAD -40 to 150; Manufacturer: Texas Instruments; Category: Integrated Circuits (ICs); Component Kind: Standard; Library Name: ics2.IntLib; Manufacturer Part Number: TPS7B8601QDDARQ1; Pricing 1: 1=2,23, 10=1,45, 50=1,45, 100=1,28, 1000=1,09, 10000=1,02 (USD); RoHS: Yes; RoHS Compliant: Yes; Stock 1: 7087; Supplier 1: Mouser; Supplier Part Number 1: 595-TPS7B8601QDDARQ1
U22 = TLV70433DBVR: IC REG LDO 3.3V 0.15A SOT23-5; Manufacturer: Texas Instruments; Category: Voltage Regulators - Linear; Component Kind: Standard; ComponentLink1Description: https://datasheet.ciiva.com/14278/tlv70433-14278163.pdf?src-supplier=Avnet; ComponentLink1URL: https://datasheet.ciiva.com/14278/tlv70433-14278163.pdf?src-supplier=Avnet; ComponentLink2Description: https://datasheet.ciiva.com/2060/tlv704-2060697.pdf?src-supplier=Digikey; ComponentLink2URL: https://datasheet.ciiva.com/2060/tlv704-2060697.pdf?src-supplier=Digikey; Library Name: ics2.IntLib; Manufacturer Part Number: TLV70433DBVR; Pricing 1: 1=0,55, 10=0,349, 50=0,349, 100=0,282, 1000=0,259, 10000=0,228 (USD); RoHS: Yes; Stock 1: 41773; Supplier 1: Mouser; Supplier Part Number 1: 595-TLV70433DBVR
U23 = SN6505BQDBVRQ1: Power Management Specialized - PMIC A 595-SN6505BQDBVTQ1; Brand: Texas Instruments; Input Current: 1.56 mA; Input Voltage Range: 2.25 V to 5.5 V; Output Current: 1 A; Product Status: New Product; Qualification: AEC-Q100; Category: Power Management Specialized - PMIC; Component Kind: Standard; Library Name: ics2.IntLib; Manufacturer: Texas Instruments; Manufacturer Part Number: SN6505BQDBVRQ1; Maximum Operating Temperature: + 125 C; Minimum Operating Temperature: - 40 C; Minimum Order Quantity: 1; Moisture Sensitive: Yes; Mounting Style: SMD/SMT; Operating Supply Current: 1.56 mA; Operating Supply Voltage: 2.25 V to 5.5 V; Package / Case: SOT-23-6; Packaging: Cut Tape; Pricing 1: 1=2.42, 10=2.18, 100=1.78, 250=1.67, 500=1.51, 1000=1.28, 3000=1.22, 6000=1.19 (EUR); Product: PMICs; Product Type: Power Management Specialized - PMIC; Reeling: True; RoHS: RoHS Compliant; RoHS - Mouser: Y; Series: SN6505B-Q1; Standard Pack Qty: 3000; Stock 1: 3000; Subcategory: PMIC - Power Management ICs; Supplier 1: Mouser; Supplier Part Number 1: 595-SN6505BQDBVRQ1; Type: Low-EMI
